# Altium schematic sheet soqueteESP32.SchDoc
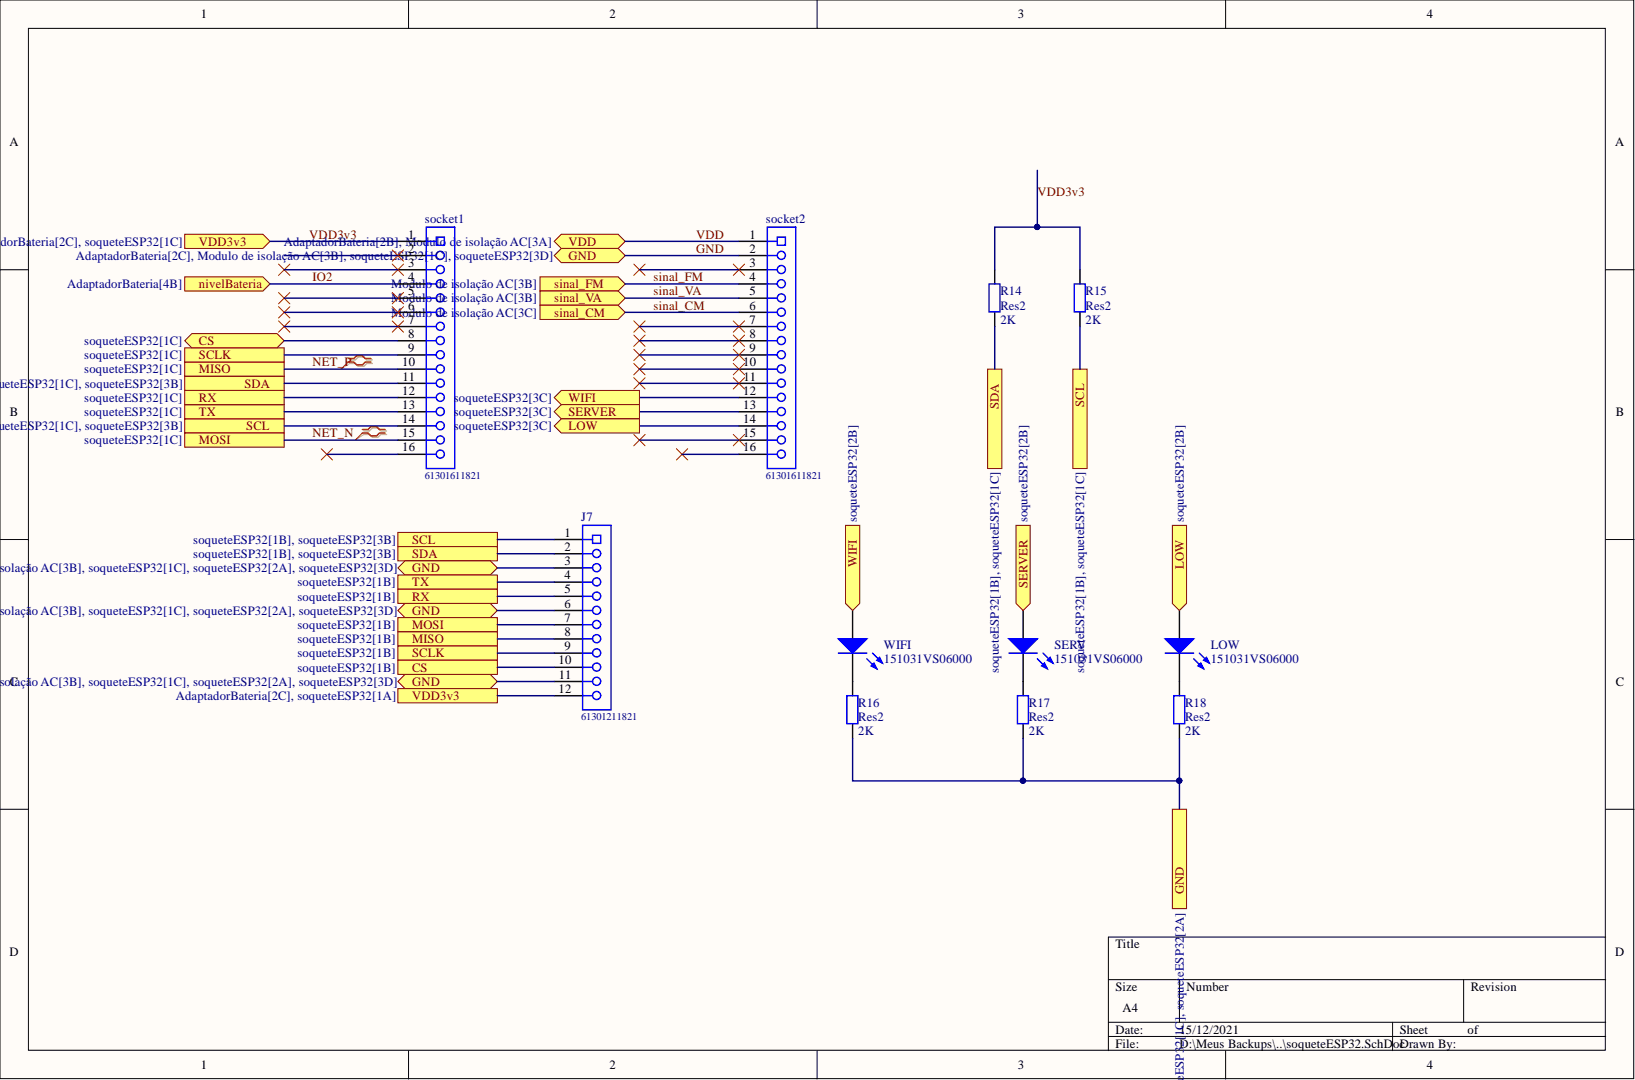
[diagram p0: soqueteESP32.SchDoc]

## Parts
J7  61301211821  [61301211821]
LOW  151031VS06000  [Type 3mm]
R14  Res2  value 2K  [AXIAL-0.4]
R15  Res2  value 2K  [AXIAL-0.4]
R16  Res2  value 2K  [AXIAL-0.4]
R17  Res2  value 2K  [AXIAL-0.4]
R18  Res2  value 2K  [AXIAL-0.4]
SERV  151031VS06000  [Type 3mm]
socket1  61301611821  [61301611821]
socket2  61301611821  [61301611821]
WIFI  151031VS06000  [Type 3mm]

## Ports
CS, GND, LOW, MISO, MOSI, nivelBateria, RX, SCL, SCLK, SDA, SERVER, sinal_CM, sinal_FM, sinal_VA, TX, VDD, VDD3v3, WIFI

## Nets
CS: J7.10 socket1.8 ; port CS
GND: J7.3 J7.6 J7.11 R16.1 R17.1 R18.1 socket2.2 ; port GND
IO2: socket1.4(de) ; port nivelBateria
LOW: LOW.2 socket2.14 ; port LOW
NET_N: J7.7 socket1.15 ; port MOSI
NET_P: J7.8 socket1.10 ; port MISO
RX: J7.5 socket1.12 ; port RX
SCL: J7.1 R15.1 socket1.14 ; port SCL
SCLK: J7.9 socket1.9 ; port SCLK
SDA: J7.2 R14.1 socket1.11 ; port SDA
SERVER: SERV.2 socket2.13 ; port SERVER
sinal_CM: socket2.6 ; port sinal_CM
sinal_FM: socket2.4 ; port sinal_FM
sinal_VA: socket2.5 ; port sinal_VA
TX: J7.4 socket1.13 ; port TX
VDD: socket2.1 ; port VDD
VDD3v3: J7.12 R14.2 R15.2 socket1.1 ; port VDD3v3
WIFI: socket2.12 WIFI.2 ; port WIFI
NetLOW_1: LOW.1 R18.2
NetR16_2: R16.2 WIFI.1
NetR17_2: R17.2 SERV.1

Not connected: socket1 2 3 5(de) 6(de) 7 16; socket2 3 7 8 9 10 11 15 16

## Library data of the parts
J7 = CMP-1502-01337-1: THT Vertical Socket Header WR-PHD, Pitch 2.54 mm, Single Row, 12 pins; Manufacturer: Wurth Elektronik; Contact Resistance: 20mOhm; Gender: Female; Pin Number: 12; Pitch: 2.54mm; Rated Current: 3A; Withstanding Voltage: 500VAC; Working Voltage: 250VAC; Component Kind: Standard; Datasheet URL: https://katalog.we-online.de/em/datasheet/6130xx11821.pdf; Library Name: Altium Content Vault; Manufacturer URL: http://www.we-online.de; Mounting Technology: THT; Operating Temperature: -40to125degC; Orientation: Vertical; Package Reference: 61301211821; Packaging: Tray; Part Number: 61301211821; Row Number: Single; Publisher: WurthElektronik
LOW SERV WIFI = CMP-1488-00004-1: THT LED round mono-color color lens, WL-TMRC , Bright Green; Manufacturer: Wuerth Elektronik; Component Kind: Standard; ComponentLink1Description: Manufacturer URL; ComponentLink1URL: http://www.we-online.com; ComponentLink2Description: Datasheet; ComponentLink2URL: http://katalog.we-online.de/pbs/datasheet/7448042001.pdfhttp://katalog.we-online.de/pbs/datasheet/7448042001.pdfhttp://katalog.we-online.de/pbs/datasheet/7448042001.pdfhttp://katalog.we-online.de/pbs/datasheet/151031VS06000.pdf; Emitting color: Bright Green; Library Name: Altium Content Vault; PackageReference: Type 3mm; PartNumber: 151031VS06000
R14-R18 = Res2: Resistor; Component Kind: Standard; LatestRevisionDate: 17-Jul-2002; LatestRevisionNote: Re-released for DXP Platform.; Library Name: Miscellaneous Devices.IntLib; PackageDescription: Axial Device, Thru-Hole; 2 Leads; 0.4 in Pin Spacing; PackageReference: AXIAL-0.4; Published: 8-Jun-2000; Signal Integrity: Res; Simulation: RESISTOR; Publisher: Altium Limited
socket1 socket2 = CMP-1502-01343-1: THT Vertical Socket Header WR-PHD, Pitch 2.54 mm, Single Row, 16 pins; Manufacturer: Wurth Elektronik; Contact Resistance: 20mOhm; Gender: Female; Pin Number: 16; Pitch: 2.54mm; Rated Current: 3A; Withstanding Voltage: 500VAC; Working Voltage: 250VAC; Component Kind: Standard; Datasheet URL: https://katalog.we-online.de/em/datasheet/6130xx11821.pdf; Library Name: Altium Content Vault; Manufacturer URL: http://www.we-online.de; Mounting Technology: THT; Operating Temperature: -40to125degC; Orientation: Vertical; Package Reference: 61301611821; Packaging: Tray; Part Number: 61301611821; Row Number: Single; Publisher: WurthElektronik
